# Altium schematic sheet QuadDeck.SchDoc
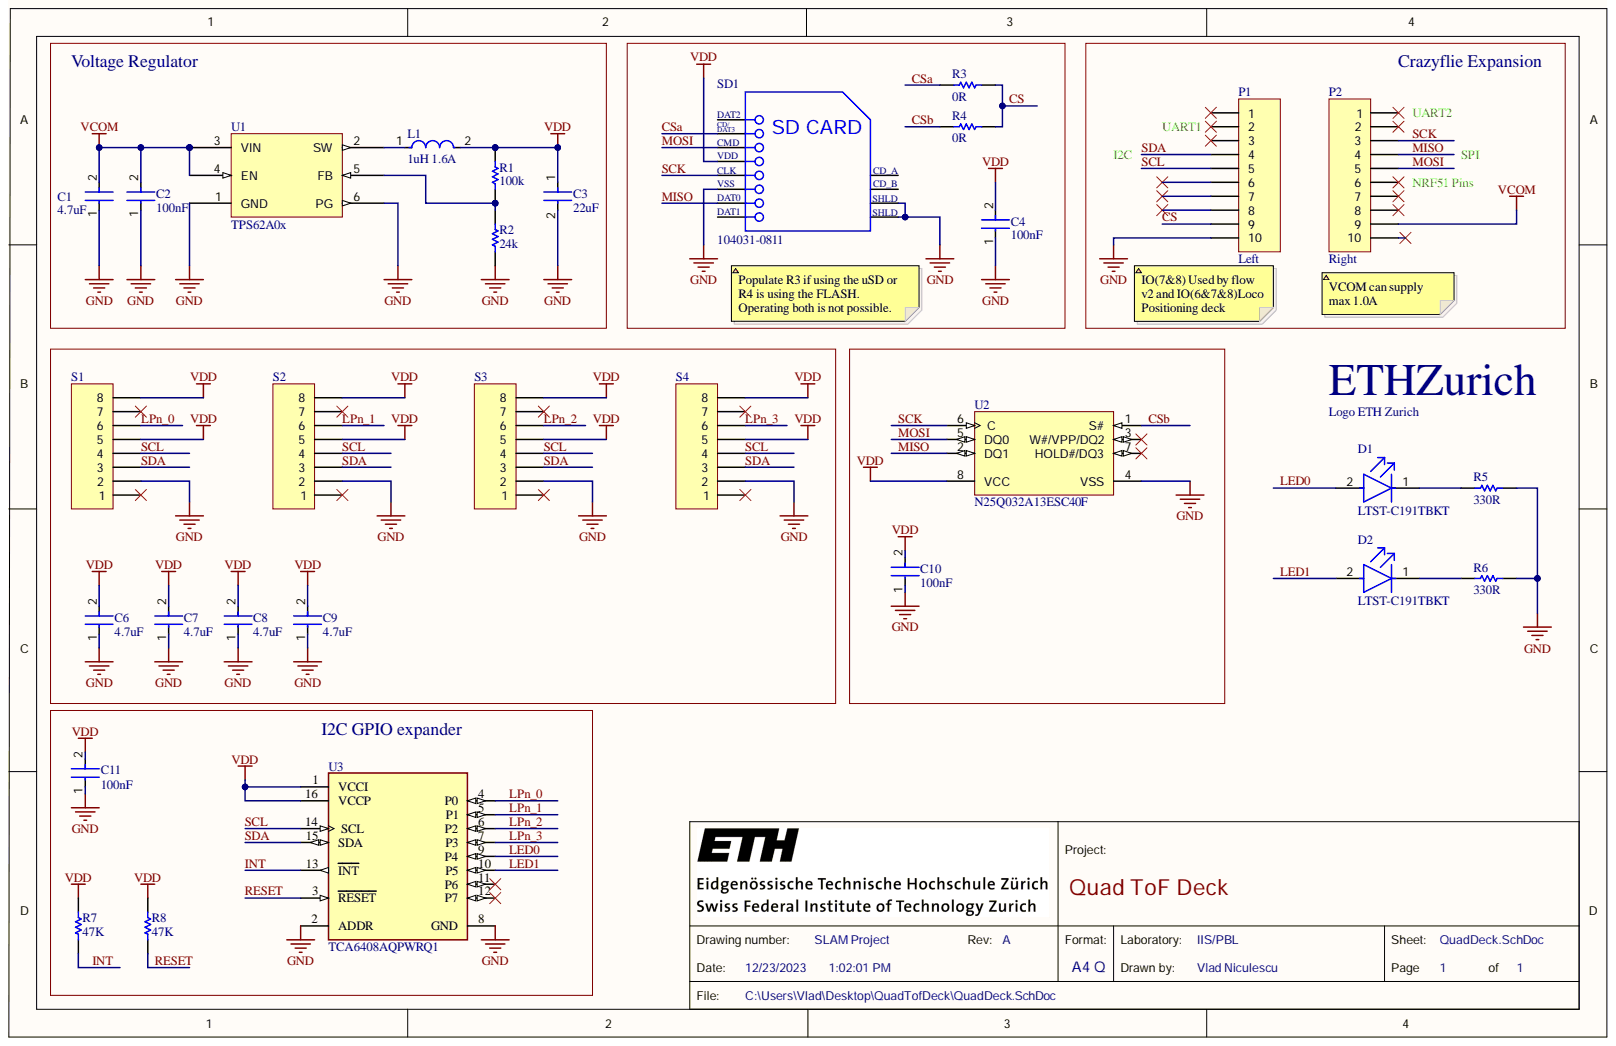
[diagram p0: QuadDeck.SchDoc]

## Parts
A1  Logo ETH Zurich  [eth-zurich_3mm]  (no BOM)
C1  4.7uF  [FP-GRM188-0_1-MFG]
C2  100nF  [FP-GRM15-MFG]
C3  22uF  [FP-GRM21B-0_15-MFG]
C4  100nF  [FP-GRM15-MFG]
C6  4.7uF  [FP-GRM188-0_1-MFG]
C7  4.7uF  [FP-GRM188-0_1-MFG]
C8  4.7uF  [FP-GRM188-0_1-MFG]
C9  4.7uF  [FP-GRM188-0_1-MFG]
C10  100nF  [FP-GRM15-MFG]
C11  100nF  [FP-GRM15-MFG]
D1  LTST-C191TBKT  [FP-LTST-C191TBKT-MFG]
D2  LTST-C191TBKT  [FP-LTST-C191TBKT-MFG]
L1  1uH 1.6A  Current Rating: 1.6A  Inductance: 1uH  [FP-LQM21PN_EH-w1_5-IPC_A]
P1  Left  [PCBComponent_1]
P2  Right  [PCBComponent_1]
R1  100k  [RESC1608X55X30ML20T20]
R2  24k  [RESC0603(1608)_N]
R3  0R  [RESC1005X40X25NL05T10]
R4  0R  [RESC1005X40X25NL05T10]
R5  330R  [RESC1608X55X25NL10T15]
R6  330R  [RESC1608X55X25NL10T15]
R7  47K  [RESC1608X55X30NL15T15]
R8  47K  [RESC1608X55X30NL15T15]
S1  Header  [tof-connector]  (value not drawn)
S2  Header  [tof-connector]  (value not drawn)
S3  Header  [tof-connector]  (value not drawn)
S4  Header  [tof-connector]  (value not drawn)
SD1  104031-0811  [MOLX-104031-0811_V]
U1  TPS62A0x  [SOT50P245X110-6N]
U2  N25Q032A13ESC40F  [MICT-SC-8_N]
U3  TCA6408AQPWRQ1  [FP-PW0016A-IPC_B]

## Nets
GND: C1.1 C2.1 C3.2 C4.1 C6.1 C7.1 C8.1 C9.1 C10.1 C11.1 P1.10 R2.1 R5.2 R6.2 S1.2 S2.2 S3.2 S4.2 SD1.6 SD1.11 SD1.12 U1.1(GND) U1.6(PG) U2.4(VSS) U3.2(ADDR) U3.8(GND)
VCOM: C1.2 C2.2 P2.9 U1.3(VIN) U1.4(EN)
VDD: C3.1 C4.2 C6.2 C7.2 C8.2 C9.2 C10.2 C11.2 L1.2 R1.2 R7.2 R8.2 S1.5 S1.8 S2.5 S2.8 S3.5 S3.8 S4.5 S4.8 SD1.4 U2.8(VCC) U3.1(VCCI) U3.16(VCCP)
~{INT}: R7.1 U3.13(INT)
~{RESET}: R8.1 U3.3(RESET)
CS: P1.9 R3.2 R4.2
CSa: R3.1 SD1.2(SD_CARD)
CSb: R4.1 U2.1(S#)
LED0: D1.2 U3.9(P4)
LED1: D2.2 U3.10(P5)
LPn_0: S1.6 U3.4(P0)
LPn_1: S2.6 U3.5(P1)
LPn_2: S3.6 U3.6(P2)
LPn_3: S4.6 U3.7(P3)
MISO: P2.4 SD1.7 U2.2(DQ1)
MOSI: P2.5 SD1.3 U2.5(DQ0)
SCK: P2.3 SD1.5 U2.6(C)
SCL: P1.5 S1.4 S2.4 S3.4 S4.4 U3.14(SCL)
SDA: P1.4 S1.3 S2.3 S3.3 S4.3 U3.15(SDA)
NetD1_1: D1.1 R5.1
NetD2_1: D2.1 R6.1
NetL1_1: L1.1 U1.2(SW)
NetR1_1: R1.1 R2.2 U1.5(FB)

Not connected: P1 1 2 3 6 7 8; P2 1 2 6 7 8 10; S1 1 7; S2 1 7; S3 1 7; S4 1 7; SD1 1 8 9 10; U2 3(W#/VPP/DQ2) 7(HOLD#/DQ3); U3 11(P6) 12(P7)

## Library data of the parts
A1 = CMP-00038-1: Library Name: Sensor_Node_Shield.IntLib
C1 C6-C9 = CMP-2006-02799-3: CAP CER 4.7UF 6.3V X5R 0603; Material: Ceramic; Tolerance: 10%; Capacitance: 4.7uF; Case Code (Imperial): 0603; Case Code (Metric): 1608; Case/Package: 0603; Component Kind: Standard; Depth: 0.8mm; Dielectric: X5R; Height: 0.8mm; Lead Free: Lead Free; Length: 1.6mm; Library Name: Altium Content Vault; Max Operating Temperature: 85°C; Min Operating Temperature: -55°C; Mount: Surface Mount; Package Quantity: 1; Packaging: Tape and Reel; Radiation Hardening: No; REACH SVHC: No SVHC; RoHS Compliant: Yes; Termination: SMD/SMT; Thickness: 0.035inch; Voltage: 6.3V; Voltage Rating: 6.3V; Voltage Rating (DC): 6.3V; Width: 0.8mm
C2 C4 C10 C11 = CMP-2100-03641-2: CAP CER 0.1UF 16V X7R 0402; Manufacturer: Murata; Manufacturer Part Number: GRM155R71C104KA88D; Capacitance: 0.10μF; Material: Ceramic; Standoff Height: 0mm; Tolerance: 10%; Value: 0.10μF; Voltage Rating: 16Vdc; Category: Cap; Component Kind: Standard; ComponentLink2Description: Datasheet; ComponentLink2URL: https://search.murata.co.jp/Ceramy/image/img/A01X/G101/ENG/GRM155R71H471KA01-01A.pdf; Device Class L1: Passive Components; Device Class L2: Capacitors; Device Class L3: Ceramic Capacitors; Digikey Description: CAP CER 0.1UF 16V X7R 0402; Height: 0.55mm; Ipc Land Pattern Name: CAPC10050X219; Lead Free: yes; Library Name: Altium Content Vault; Mouser Description: 0402 0.1 uF 16V ±10 % Tolerance X7R SMT Multilayer Ceramic Capacitor; Octopart: https://octopart.com/grm155r71c104ka88d-murata-196880; Package: GRM15; RoHS: TRUE; Temperature: -55°C to +125°C; Temperature Characteristic: X7R; Temperature Range High: +125°C; Temperature Range Low: -55°C
C3 = CMP-2007-03385-2: Chip Multilayer Ceramic Capacitors for General Purpose, 0805, 22uF, X5R, 15%, 20%, 6.3V; Capacitance: 22uF; Case Code (Imperial): 0805; Case Code (Metric): 2012; Case/Package: 0805; Component Kind: Standard; Depth: 1.25mm; Dielectric: X5R; Height: 1.25mm; Lead Free: Lead Free; Length: 2mm; Library Name: Altium Content Vault; Material: Ceramic; Max Operating Temperature: 85°C; Min Operating Temperature: -55°C; Mount: Surface Mount; Package Quantity: 1; Packaging: Tape and Reel; Radiation Hardening: No; REACH SVHC: No SVHC; Termination: SMD/SMT; Thickness: 0.053inch; Tolerance: 20%; Voltage: 6.3V; Voltage Rating: 6.3V; Voltage Rating (DC): 6.3V; Width: 0.049inch
D1 D2 = CMP-22017-000008-1: LED BLUE CLEAR CHIP SMD; Dominant Wavelength: 475nm; Forward Current: 20mA; Forward Voltage: 3.8V; Lens Color: Colorless; Lens Style: Diffused; Luminous Intensity: 180mcd; Max Power Dissipation: 75mW; Number of Elements: 1; Power Dissipation: 76mW; Reverse Voltage: 5V; Viewing Angle: 130°; Wavelength: 468nm; Case Code (Imperial): 0603; Case Code (Metric): 1608; Case/Package: 0603; Color: Blue; Component Kind: Standard; Depth: 1.6mm; Height: 0.55mm; Illumination Color: Blue; Lead Free: Lead Free; Length: 0.8mm; Lens Transparency: Clear; Library Name: Altium Content Vault; Max Operating Temperature: 80°C; Min Operating Temperature: -20°C; Mount: Surface Mount; Number of LEDs: 1; Number of Pins: 2; Package Quantity: 1; Packaging: Tape and Reel; Radiation Hardening: No; Test Current: 20mA; Width: 0.8mm
L1 = CMP-06042-012339-2: FIXED IND 1UH 1.6A 107 MOHM SMD; Core Material: Ferrite; DC Resistance (DCR): 107mR; Saturation Current: 1A; Self Resonant Frequency: 50MHz; Shielding: Shielded; Test Frequency: 1MHz; Tolerance: 20%; Case Code (Imperial): 0805; Case Code (Metric): 2012; Case/Package: 0805; Component Kind: Standard; Library Name: Altium Content Vault; Max Operating Temperature: 85°C; Min Operating Temperature: -40°C; Mount: Surface Mount; Packaging: Tape and Reel; RoHS Compliant: Yes
P1 P2 = MHDR1X10: Header, 10-Pin; Component Kind: Standard; LatestRevisionDate: 17-Jul-2002; LatestRevisionNote: Re-released for DXP Platform.; Library Name: Miscellaneous Connectors.IntLib; Publisher: Altium Limited
R1 = CMP-2000-00875-1: Manufacturer: Panasonic; Part Number: ERA3AEB104V; Component Kind: Standard; Library Name: Altium Content Vault
R2 = CMP-1012-00107-1: 24K 0.1W 5% 0603 (1608 Metric)  SMD; Case-EIA: 0603; Case-Metric: 1608; Value: 24000.00 Ohm; Component Kind: Standard; Library Name: Altium Content Vault; Power: 0.1 W; Technology: SMT; Tolerance: 5 %
R3 R4 = CMP-1011-00001-2: Manufacturer: Yageo / Phycomp; Part Number: RC0402JR-070RL; Component Kind: Standard; Library Name: Altium Content Vault
R5 R6 = CMP-1659-00020-2: Chip Resistor, 330 Ohm, +/- 1%, 0.1 W, -55 to 155 degC, 0603 (1608 Metric), RoHS, Tape and Reel; Capacitance: 47 uF; ESR: 800 mohm; Tolerance: 10%; Voltage Rating: 16 V; Case Code (Imperial): 2917; Case Code (Metric): 7343; Case/Package: 2917; Component Kind: Standard; ComponentLink1Description: Manufacturer URL; ComponentLink1URL: http://www.yageo.com/; ComponentLink2Description: Datasheet; ComponentLink2URL: http://www.yageo.com/documents/recent/PYu-RC_Group_51_RoHS_L_04.pdf; DatasheetVersion: Rev. 4, 02/2016; Height: 3.1 mm; Length: 7.3 mm; Library Name: Altium Content Vault; Max Operating Temperature: 125degC; Min Operating Temperature: -55degC; Mount: Surface Mount; Package Quantity: 500; PackageDescription: 2-Pin Surface Mount Device, Body 1.6 x 0.8 mm; PackageReference: 0603; Packaging: Tape and Reel; Pins: 2; Radiation Hardening: No; REACH SVHC: No SVHC; RoHSCompliant: True; Voltage Rating (DC): 16 V; Width: 4.3 mm
R7 R8 = CMP-2000-00523-2: Chip Resistor, 47 KOhm, +/- 1%, 0.1 W, -55 to 155 degC, 0603 (1608 Metric), RoHS, Tape and Reel; Case\Package: 0603; Forward Current: 20 mA; Forward Voltage: 2.1 V; Illumination Colour: Red; Lens Style: Clear; Luminous Intensity: 63 mcd; Nominal Wavelength: 639 nm; Power Rating: 90 mW; Viewing Angle: 160 degrees; Case Code (Imperial): 0603; Case Code (Metric): 1608; Colour: Red; Component Kind: Standard; ComponentLink1Description: Manufacturer URL; ComponentLink1URL: http://www.panasonic.com/; ComponentLink2Description: Datasheet; ComponentLink2URL: http://industrial.panasonic.com/cdbs/www-data/pdf/RDA0000/AOA0000C86.pdf; DatasheetVersion: Apr-15; Height: 0.6 mm; Length: 1.6 mm; Library Name: Altium Content Vault; Max Operating Temperature: 100 degC; Min Operating Temperature: -40 degC; Mount: Surface Mount; Nominal Vf: 2.1 V; Package Quantity: 3000; PackageDescription: 2-Pin Surface Mount Device, Body 1.6 x 0.85 mm; PackageReference: 0603; Packaging: Tape and Reel; Pins: 2; Radiation Hardening: No; REACH SVHC: No SVHC; RoHSCompliant: true; Width: 0.8 mm
S1-S4 = Header 8: Header, 8-Pin; Component Kind: Standard; LatestRevisionDate: 17-Jul-2002; LatestRevisionNote: Re-released for DXP Platform.; Library Name: Miscellaneous Connectors.IntLib; Publisher: Altium Limited
SD1 = CMP-2000-05825-1: Micro SD Card, RA, -25 to 85 degC, 8-Pin SMD, RoHS, Tape and Reel; Brand: Molex; Current Rating: 500mA; Insertion - Extraction Style: Push-Pull; Mating Cycles: 10000; Number of Contacts: 8; Pitch: 1.1mm; Voltage Rating: 10VAC/DC; Card Type: microSD; Component Kind: Standard; Contact Material: PhosphorBronze; Contact Plating: Gold; Datasheet URL: http://www.molex.com/pdm_docs/sd/1040310811_sd.pdf; Datasheet Version: Rev. E, 04/2006; Height: 1.42mm; Housing Material: LiquidCrystalPolymer(LCP); Length: 11.4mm; Library Name: Altium Content Vault; Manufacturer: Molex; Manufacturer URL: http://www.molex.com/; Mounting Technology: SurfaceMount; Mounting Type: Standard; Number of Rows: 1Row; Orientation: RightAngle; Package Description: 8-Pin Surface Mount Device, Body 11.95 x 11.4 mm, Pitch 1.1 mm; Package Reference: 104031-0811; Packaging: Reel; Product: CardConnectors; Product Category: MemoryCardConnectors; RoHS: True; Series: 104031; Termination Style: SMD
U1 = TPS62A01: Component Kind: Standard; Library Name: TPS62A01.SchLib
U2 = CMP-0357-00152-1: 32 Mb (x1/x2/x4) Serial NOR Flash Memory with Multi I/O, 108 MHz, 2.7 to 3.6 V, 8-pin SO8 Narrow (SC), Tape & Reel; Manufacturer: Micron; Part Family: N25QÂ; Density: 32MbÂ; Speed: 108 MHzÂ; Voltage: 2.7V-3.6VÂ; Applications: EmbeddedÂ; Component Kind: Standard; ComponentLink1Description: Manufacturer URL; ComponentLink1URL: http://www.micron.com/; ComponentLink2Description: Datasheet; ComponentLink2URL: http://www.micron.com/~/media/Documents/Products/Data%20Sheet/NOR%20Flash/Serial%20NOR/N25Q/n25q_32mb_3v_65nm.pdf; ComponentLink3Description: Package Specification; ComponentLink3URL: http://www.micron.com/~/media/Documents/Products/Data%20Sheet/NOR%20Flash/Serial%20NOR/N25Q/n25q_32mb_3v_65nm.pdf; DatasheetVersion: Rev. G; Library Name: Altium Content Vault; Mounting Technology: Surface Mount; Package: SO8 NarrowÂ; PackageDescription: 8-Pin SO Narrow, 3.9 X 4.9 mm, Pitch 1.27 mm; PackageReference: MICT-SC-8; PackageVersion: Rev. E; Part Status: ProductionÂ; PartNumber: N25Q032A13ESC40F; RoHS: YesÂ; Type: Multi I/OÂ; Width: x1/x2/x4Â
U3 = CMP-04886-000007-1: IC I/O EXPANDER I2C 8B 16TSSOP; Number of I/Os: 8; Case/Package: TSSOP; Component Kind: Standard; Lead Free: Lead Free; Library Name: Altium Content Vault; Max Operating Temperature: 125°C; Min Operating Temperature: -40°C; RoHS Compliant: Yes
